# Altium schematic sheet Sch9 - Isoladores dos Radares.SchDoc("Sch9 - Isoladores dos Radares")
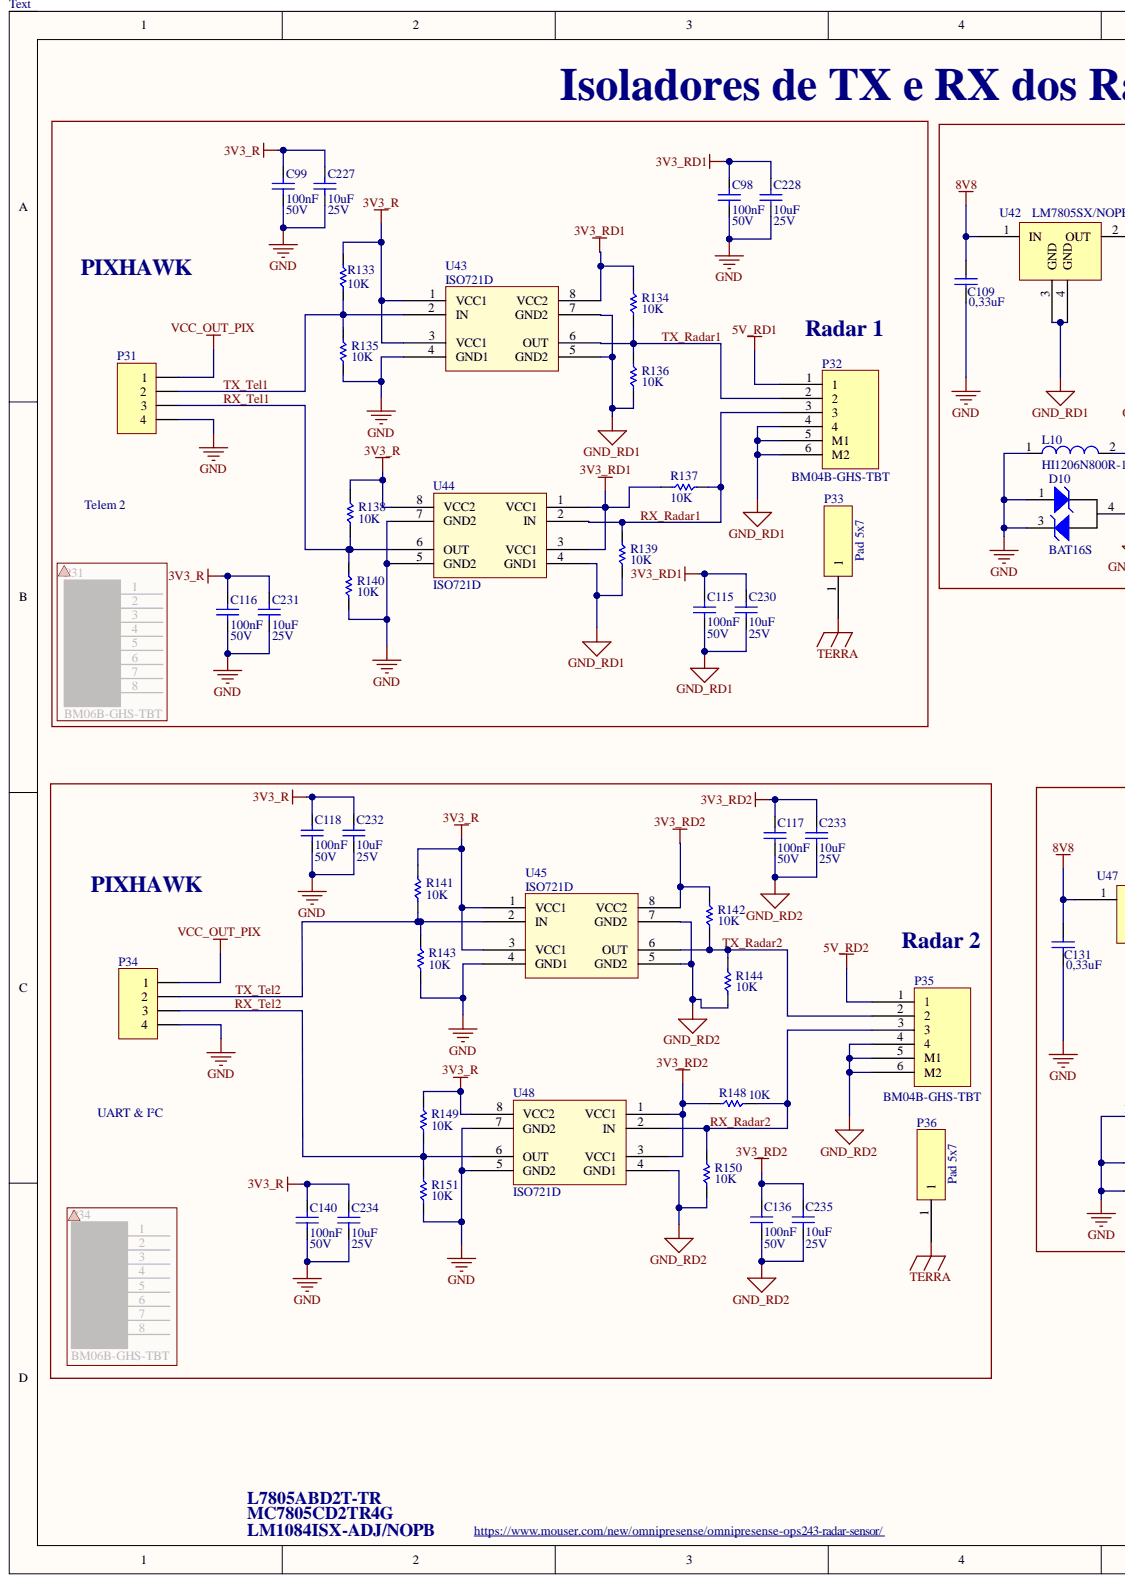
[diagram p0: Sch9 - Isoladores dos Radares.SchDoc, left]
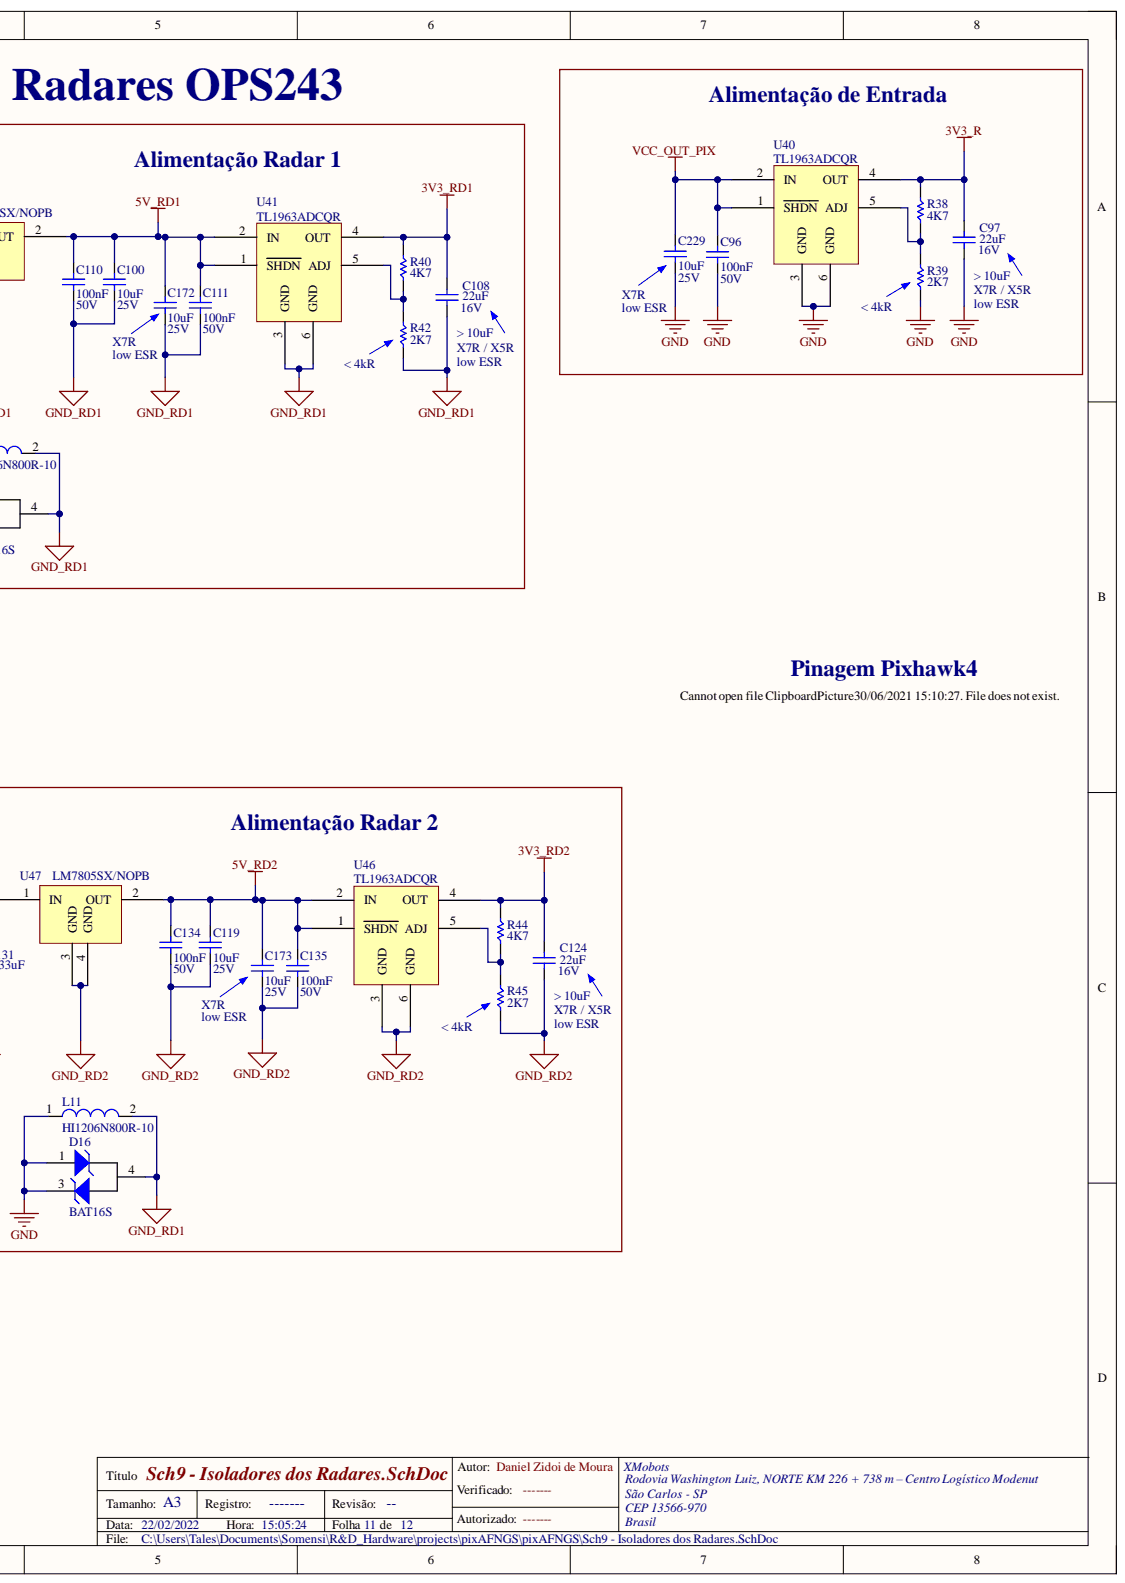
[diagram p1: Sch9 - Isoladores dos Radares.SchDoc, right]

## Parts
C96  Capacitance: 100nF  Voltage: 50V  [0603]  (hidden comment: CL10B104MB8NNNC)
C97  Capacitance: 22uF  Voltage: 16V  [SMD-CER-1206]  (hidden comment: CL31A226KOCLNNC)
C98  Capacitance: 100nF  Voltage: 50V  [0603]  (hidden comment: CL10B104MB8NNNC)
C99  Capacitance: 100nF  Voltage: 50V  [0603]  (hidden comment: CL10B104MB8NNNC)
C100  Capacitance: 10uF  Voltage: 25V  [0603]  (hidden comment: GRM188R61E106KA73J)
C108  Capacitance: 22uF  Voltage: 16V  [SMD-CER-1206]  (hidden comment: CL31A226KOCLNNC)
C109  value 0,33uF  [0603]  (hidden comment: C1608X7S2A104K080AE)
C110  Capacitance: 100nF  Voltage: 50V  [0603]  (hidden comment: CL10B104MB8NNNC)
C111  Capacitance: 100nF  Voltage: 50V  [0603]  (hidden comment: CL10B104MB8NNNC)
C115  Capacitance: 100nF  Voltage: 50V  [0603]  (hidden comment: CL10B104MB8NNNC)
C116  Capacitance: 100nF  Voltage: 50V  [0603]  (hidden comment: CL10B104MB8NNNC)
C117  Capacitance: 100nF  Voltage: 50V  [0603]  (hidden comment: CL10B104MB8NNNC)
C118  Capacitance: 100nF  Voltage: 50V  [0603]  (hidden comment: CL10B104MB8NNNC)
C119  Capacitance: 10uF  Voltage: 25V  [0603]  (hidden comment: GRM188R61E106KA73J)
C124  Capacitance: 22uF  Voltage: 16V  [SMD-CER-1206]  (hidden comment: CL31A226KOCLNNC)
C131  value 0,33uF  [0603]  (hidden comment: C1608X7S2A104K080AE)
C134  Capacitance: 100nF  Voltage: 50V  [0603]  (hidden comment: CL10B104MB8NNNC)
C135  Capacitance: 100nF  Voltage: 50V  [0603]  (hidden comment: CL10B104MB8NNNC)
C136  Capacitance: 100nF  Voltage: 50V  [0603]  (hidden comment: CL10B104MB8NNNC)
C140  Capacitance: 100nF  Voltage: 50V  [0603]  (hidden comment: CL10B104MB8NNNC)
C172  Capacitance: 10uF  Voltage: 25V  [0603]  (hidden comment: GRM188R61E106KA73J)
C173  Capacitance: 10uF  Voltage: 25V  [CAP-XMO-0603]  (hidden comment: GRM188R61E106KA73J)
C227  Capacitance: 10uF  Voltage: 25V  [CAP-XMO-0603]  (hidden comment: GRM188R61E106KA73J)
C228  Capacitance: 10uF  Voltage: 25V  [CAP-XMO-0603]  (hidden comment: GRM188R61E106KA73J)
C229  Capacitance: 10uF  Voltage: 25V  [0603]  (hidden comment: GRM188R61E106KA73J)
C230  Capacitance: 10uF  Voltage: 25V  [CAP-XMO-0603]  (hidden comment: GRM188R61E106KA73J)
C231  Capacitance: 10uF  Voltage: 25V  [CAP-XMO-0603]  (hidden comment: GRM188R61E106KA73J)
C232  Capacitance: 10uF  Voltage: 25V  [CAP-XMO-0603]  (hidden comment: GRM188R61E106KA73J)
C233  Capacitance: 10uF  Voltage: 25V  [CAP-XMO-0603]  (hidden comment: GRM188R61E106KA73J)
C234  Capacitance: 10uF  Voltage: 25V  [CAP-XMO-0603]  (hidden comment: GRM188R61E106KA73J)
C235  Capacitance: 10uF  Voltage: 25V  [CAP-XMO-0603]  (hidden comment: GRM188R61E106KA73J)
D10  BAT16S  [SOT223-4]
D16  BAT16S  [SOT223-4]
L10  HI1206N800R-10  [1206]
L11  HI1206N800R-10  [1206]
P31  J-CON-4VIAS-TH  [J-CON-4VIAS-TH]  (value not drawn)
P32  BM04B-GHS-TBT  [BM04B-GHS-TBT]
P33  Pad 5x7  [Pad de solda 5x7]
P34  J-CON-4VIAS-TH  [J-CON-4VIAS-TH]  (value not drawn)
P35  BM04B-GHS-TBT  [BM04B-GHS-TBT]
P36  Pad 5x7  [Pad de solda 5x7]
R38  Resistance: 4K7  [Res_0603]  (hidden comment: RMCF0603FT4K70)
R39  Resistance: 2K7  [Res_0603]  (hidden comment: CRGCQ0603F2K7)
R40  Resistance: 4K7  [Res_0603]  (hidden comment: RMCF0603FT4K70)
R42  Resistance: 2K7  [Res_0603]  (hidden comment: CRGCQ0603F2K7)
R44  Resistance: 4K7  [Res_0603]  (hidden comment: RMCF0603FT4K70)
R45  Resistance: 2K7  [Res_0603]  (hidden comment: CRGCQ0603F2K7)
R133  Resistance: 10K  [RES-XMO-0603]  (hidden comment: RMCF0603FT10K0)
R134  Resistance: 10K  [RES-XMO-0603]  (hidden comment: RMCF0603FT10K0)
R135  Resistance: 10K  [RES-XMO-0603]  (hidden comment: RMCF0603FT10K0)
R136  Resistance: 10K  [RES-XMO-0603]  (hidden comment: RMCF0603FT10K0)
R137  Resistance: 10K  [RES-XMO-0603]  (hidden comment: RMCF0603FT10K0)
R138  Resistance: 10K  [RES-XMO-0603]  (hidden comment: RMCF0603FT10K0)
R139  Resistance: 10K  [RES-XMO-0603]  (hidden comment: RMCF0603FT10K0)
R140  Resistance: 10K  [RES-XMO-0603]  (hidden comment: RMCF0603FT10K0)
R141  Resistance: 10K  [RES-XMO-0603]  (hidden comment: RMCF0603FT10K0)
R142  Resistance: 10K  [RES-XMO-0603]  (hidden comment: RMCF0603FT10K0)
R143  Resistance: 10K  [RES-XMO-0603]  (hidden comment: RMCF0603FT10K0)
R144  Resistance: 10K  [RES-XMO-0603]  (hidden comment: RMCF0603FT10K0)
R148  Resistance: 10K  [RES-XMO-0603]  (hidden comment: RMCF0603FT10K0)
R149  Resistance: 10K  [RES-XMO-0603]  (hidden comment: RMCF0603FT10K0)
R150  Resistance: 10K  [RES-XMO-0603]  (hidden comment: RMCF0603FT10K0)
R151  Resistance: 10K  [RES-XMO-0603]  (hidden comment: RMCF0603FT10K0)
U40  TL1963ADCQR  [SOT127_R-PDSO-G6]
U41  TL1963ADCQR  [SOT127_R-PDSO-G6]
U42  LM7805SX/NOPB  [LM7805SX/NOPB]
U43  ISO721D  [SOIC-8_D-PDSO-G8]
U44  ISO721D  [SOIC-8_D-PDSO-G8]
U45  ISO721D  [SOIC-8_D-PDSO-G8]
U46  TL1963ADCQR  [SOT127_R-PDSO-G6]
U47  LM7805SX/NOPB  [LM7805SX/NOPB]
U48  ISO721D  [SOIC-8_D-PDSO-G8]

## Nets
3V3_R: C97.2 C99.2 C116.2 C118.2 C140.2 C227.2 C231.2 C232.2 C234.2 R38.2 R133.2 R138.2 R141.2 R149.2 U40.4(OUT) U43.1(VCC1) U43.3(VCC1) U44.8(VCC2) U45.1(VCC1) U45.3(VCC1) U48.8(VCC2)
3V3_RD1: C98.2 C108.2 C115.2 C228.2 C230.2 R40.2 R134.2 R137.2 U41.4(OUT) U43.8(VCC2) U44.1(VCC1) U44.3(VCC1)
3V3_RD2: C117.2 C124.2 C136.2 C233.2 C235.2 R44.2 R142.2 R148.2 U45.8(VCC2) U46.4(OUT) U48.1(VCC1) U48.3(VCC1)
5V_RD1: C100.2 C110.2 C111.2 C172.2 P32.1 U41.1(SHDN) U41.2(IN) U42.2(OUT)
5V_RD2: C119.2 C134.2 C135.2 C173.2 P35.1 U46.1(SHDN) U46.2(IN) U47.2(OUT)
8V8: C109.2 C131.2 U42.1(IN) U47.1(IN)
GND: C96.1 C97.1 C98.1 C99.1 C109.1 C116.1 C118.1 C131.1 C140.1 C227.1 C228.1 C229.1 C231.1 C232.1 C234.1 D10.1 D10.3 D16.1 D16.3 L10.1 L11.1 P31.4 P34.4 R39.1 R135.1 R140.1 R143.1 R151.1 U40.3(GND) U40.6(GND) U43.4(GND1) U44.5(GND2) U44.7(GND2) U45.4(GND1) U48.5(GND2) U48.7(GND2)
GND_RD1: C100.1 C108.1 C110.1 C111.1 C115.1 C172.1 C230.1 D10.4 D16.4 L10.2 L11.2 P32.4 P32.5(M1) P32.6(M2) R42.1 R136.1 R139.1 U41.3(GND) U41.6(GND) U42.3(GND) U42.4(GND) U43.5(GND2) U43.7(GND2) U44.4(GND1)
GND_RD2: C117.1 C119.1 C124.1 C134.1 C135.1 C136.1 C173.1 C233.1 C235.1 P35.4 P35.5(M1) P35.6(M2) R45.1 R144.1 R150.1 U45.5(GND2) U45.7(GND2) U46.3(GND) U46.6(GND) U47.3(GND) U47.4(GND) U48.4(GND1)
TERRA: P33.1 P36.1
VCC_OUT_PIX: C96.2 C229.2 P31.1 P34.1 U40.1(SHDN) U40.2(IN)
RX_Radar1: P32.3 R137.1 R139.2 U44.2(IN)
RX_Radar2: P35.3 R148.1 R150.2 U48.2(IN)
RX_Tel2: P34.3 R149.1 R151.2 U48.6(OUT)
TX_Radar1: P32.2 R134.1 R136.2 U43.6(OUT)
TX_Radar2: P35.2 R142.1 R144.2 U45.6(OUT)
TX_Tel2: P34.2 R141.1 R143.2 U45.2(IN)
NetP31_2: P31.2 R133.1 R135.2 U43.2(IN)
NetP31_3: P31.3 R138.1 R140.2 U44.6(OUT)
NetR38_1: R38.1 R39.2 U40.5(ADJ)
NetR40_1: R40.1 R42.2 U41.5(ADJ)
NetR44_1: R44.1 R45.2 U46.5(ADJ)
RX_Tel1:
TX_Tel1:

Not connected: D10 2; D16 2

## Library data of the parts
C96 C98 C99 C110 C111 C115-C118 C134-C136 C140 = C-100nF-50V-X7R-0603: Capacitor 100nF 50V X7R 0603; Component Kind: Standard; Part Number: CL10B104MB8NNNC; Library Name: xmobots_-_comp_library.IntLib
C97 C108 C124 = C-22uF-16V-X5R-1206: Capacitor 22uF 16V X5R 1206; Component Kind: Standard; Part Number: CL31A226KOCLNNC; Library Name: xmobots_-_comp_library.IntLib
C100 C119 C172 C173 C227-C235 = C-CER-10uF-25V-X5R-0603: Capacitor 10uF 25V X5R 0603; Component Kind: Standard; Part Number: GRM188R61E106KA73J; Library Name: xmobots_-_comp_library.IntLib
C109 C131 = Cap Semi: Capacitor (Semiconductor SIM Model); Component Kind: Standard; LatestRevisionDate: 17-Jul-2002; LatestRevisionNote: Re-released for DXP Platform.; Library Name: Miscellaneous Devices.IntLib; PackageReference: C1206; Published: 8-Jun-2000; Signal Integrity: Cap Semi; Simulation: CAP; Publisher: Altium Limited
D10 D16 = BAT16S: DIODE ARRAY SCHOTTKY 60V SOT223; Component Kind: Standard; Library Name: XM_Diodes.SchLib
L10 L11 = BLM18SG221TN1D: FERRITE CHIP 220 OHM 2500MA 0603; Component Kind: Standard; Supplier 1: Digi-Key; Supplier Part Number 1: 490-5225-1-ND; Library Name: XM_Inductor.SchLib
P32 P35 = BM04B-GHS-TBT: JST GH 4 Vias Vertical; Component Kind: Standard; Library Name: XM_Connector.SchLib
P33 P36 = 1528-2: TERMINAL TURRET SINGLE .082" L; Component Kind: Standard; Supplier 1: Digi-Key; Supplier Part Number 1: 1528-2K-ND; Library Name: XM_Connector.SchLib
R38 R40 R44 = R-4K7-1/10W-1%-0603: Resistor 4k7 ohms 1/10W 1% 0603; Component Kind: Standard; Part Number: RMCF0603FT4K70; Power: 1/10W; Tolerance: ±1%; Library Name: xmobots_-_comp_library.IntLib
R39 R42 R45 = R-2K7-1/10W-1%-0603: Resistor 2k7 ohms 1/10W 1% 0603; Component Kind: Standard; Part Number: CRGCQ0603F2K7; Power: 1/10W; Tolerance: ±1%; Library Name: xmobots_-_comp_library.IntLib
R133-R144 R148-R151 = R-10K-1/10W-1%-0603: Resistor 10k ohms 1/10W 1% 0603; Component Kind: Standard; Part Number: RMCF0603FT10K0; Power: 1/10W; Tolerance: ±1%; Library Name: xmobots_-_comp_library.IntLib
U40 U41 U46 = TL1963ADCQR: 1.5-A LOW-NOISE FAST-TRANSIENT-RESPONSE LOW-DROPOUT REGULATOR; Component Kind: Standard; Supplier 1: Digi-Key; Supplier Part Number 1: 296-25119-1-ND; Library Name: XM_IC.SchLib
U42 U47 = LM7805SX/NOPB: Linear Voltage Regulator IC Positive Fixed 1 Output 1.5A DDPAK/TO-263-3; Component Kind: Standard; Library Name: XM_IC.SchLib
U43-U45 U48 = ISO721D: 3.3-V / 5-V HIGH-SPEED DIGITAL ISOLATORS; Component Kind: Standard; Supplier 1: Digi-Key; Supplier Part Number 1: 296-19382-ND; Library Name: XM_IC.SchLib
P31 P34 = J-CON-4VIAS-TH: Component Kind: Standard; Library Name: WarLib.IntLib
